# Altium schematic sheet STM32F405.SchDoc
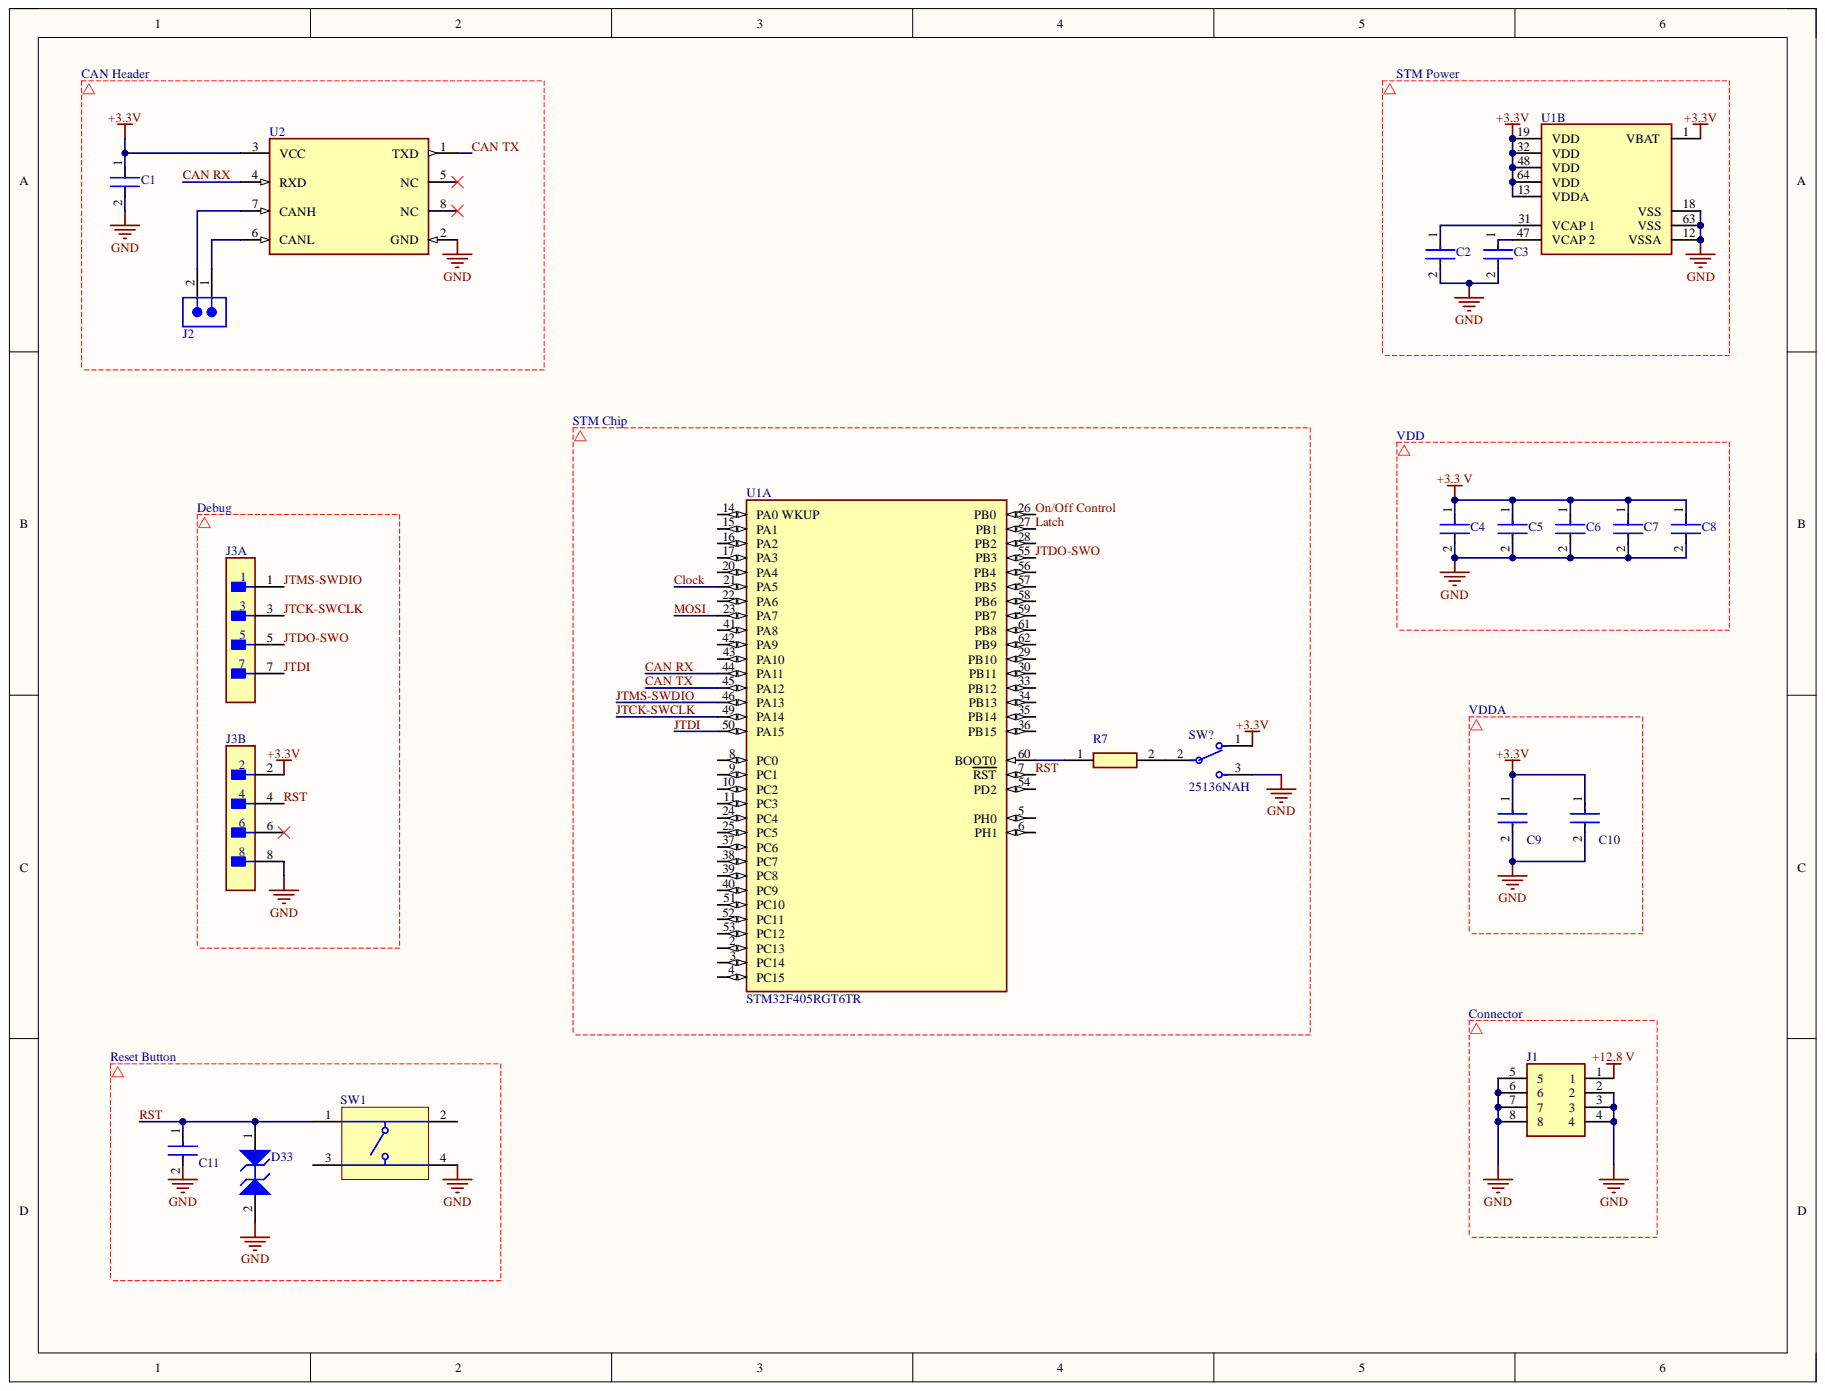
[diagram p0: STM32F405.SchDoc]

## Parts
C1  CGA3E2X7R1H104K080AA  [FP-CGA3E-0_3-0_1-IPC_A]  (value not drawn)
C2  C1005X5R1V225K050BC  [FP-C1005-050-0_15-IPC_A]  (value not drawn)
C3  C1005X5R1V225K050BC  [FP-C1005-050-0_15-IPC_A]  (value not drawn)
C4  CGA2B3X7R1E104KT  [FP-CGA2B-IPC_A]  (value not drawn)
C5  CGA2B3X7R1E104KT  [FP-CGA2B-IPC_A]  (value not drawn)
C6  CGA2B3X7R1E104KT  [FP-CGA2B-IPC_A]  (value not drawn)
C7  CGA2B3X7R1E104KT  [FP-CGA2B-IPC_A]  (value not drawn)
C8  C1005X5R1A475K050BC  [FP-C1005-050-0_15-MFG]  (value not drawn)
C9  CGA2B3X7R1E104K050BB  [FP-CGA2B-IPC_B]  (value not drawn)
C10  GRM155R61E105KA12D  [FP-GRM155-0_05-IPC_B]  (value not drawn)
C11  CL31B104KBP5PNF  [FP-CL31-IPC_C]  (value not drawn)
D33  ESD5B5.0ST1G  [ONSC-SOD-523-2-502-01_C_V]  (value not drawn)
J1  43045-0800  [43045-08(00,01,02,10)]  (value not drawn)
J2  679302124022  [679302124022]  (value not drawn)
J3  M20-9980446  [FP-M20-9980446-MFG]  (value not drawn)  (parts A,B)
R7  RP73PF1E10KBTD  [RESC1005X35N]  (value not drawn)
SW1  FSM4JSMATR  [TECO-FSM4JSMATR_V]  (value not drawn)
SW?  25136NAH  [FP-25136NAH-MFG]
U1  STM32F405RGT6TR  [FP-LQFP64-5W-IPC_C]  (parts A,B)
U2  TCAN332DCNR  [FP-DCN0008A-IPC_A]  (value not drawn)

## Nets
+3.3 V: C1.1 C4.1 C5.1 C6.1 C7.1 C8.1 C9.1 C10.1 J3.2 SW?.1 U1.1(VBAT) U1.13(VDDA) U1.19(VDD) U1.32(VDD) U1.48(VDD) U1.64(VDD) U2.3(VCC)
+12.8 V: J1.1
GND: C1.2 C2.2 C3.2 C4.2 C5.2 C6.2 C7.2 C8.2 C9.2 C10.2 C11.2 D33.2 J1.2 J1.3 J1.4 J1.5 J1.6 J1.7 J1.8 J3.8 SW1.4 SW?.3 U1.12(VSSA) U1.18(VSS) U1.63(VSS) U2.2(GND)
CAN RX: U1.44(PA11) U2.4(RXD)
CAN TX: U1.45(PA12) U2.1(TXD)
Clock: U1.21(PA5)
JTCK-SWCLK: J3.3 U1.49(PA14)
JTDI: J3.7 U1.50(PA15)
JTDO-SWO: J3.5 U1.55(PB3)
JTMS-SWDIO: J3.1 U1.46(PA13)
Latch: U1.27(PB1)
MOSI: U1.23(PA7)
On/Off Control: U1.26(PB0)
RST: C11.1 D33.1 J3.4 SW1.1 U1.7(RST)
NetC2_1: C2.1 U1.31(VCAP_1)
NetC3_1: C3.1 U1.47(VCAP_2)
NetJ2_1: J2.1 U2.6(CANL)
NetJ2_2: J2.2 U2.7(CANH)
NetR7_1: R7.1 U1.60(BOOT0)
NetR7_2: R7.2 SW?.2

Not connected: J3 6; SW1 2 3; U1 2(PC13) 3(PC14) 4(PC15) 5(PH0) 6(PH1) 8(PC0) 9(PC1) 10(PC2) 11(PC3) 14(PA0_WKUP) 15(PA1) 16(PA2) 17(PA3) 20(PA4) 22(PA6) 24(PC4) 25(PC5) 28(PB2) 29(PB10) 30(PB11) 33(PB12) 34(PB13) 35(PB14) 36(PB15) 37(PC6) 38(PC7) 39(PC8) 40(PC9) 41(PA8) 42(PA9) 43(PA10) 51(PC10) 52(PC11) 53(PC12) 54(PD2) 56(PB4) 57(PB5) 58(PB6) 59(PB7) 61(PB8) 62(PB9); U2 5(NC) 8(NC)

## Library data of the parts
C1 = CMP-2000-07546-2: Cap Ceramic 100nF 50V X7R 10% Pad SMD 0603 +125°C Automotive T/R; Capacitance: 100nF; Case Code (Imperial): 0603; Case Code (Metric): 1608; Case/Package: 0603; Component Kind: Standard; Composition: Ceramic; Depth: 0.8mm; Dielectric: X7R; Height: 0.8mm; Lead Free: Lead Free; Length: 1.6mm; Library Name: Altium Content Vault; Max Operating Temperature: 125°C; Min Operating Temperature: -55°C; Mount: Surface Mount; Package Quantity: 1; Packaging: Tape and Reel; Radiation Hardening: No; Ratings: AEC-Q200; REACH SVHC: No SVHC; Termination: SMD/SMT; Thickness: 0.035inch; Tolerance: 10%; Voltage: 50V; Voltage Rating: 50V; Voltage Rating (DC): 50V; Weight: 7.1E-05oz; Width: 0.8mm
C2 C3 = CMP-08246-007153-1: CAP CER 2.2UF 35V X5R 0402; Dielectric Material: Ceramic; Tolerance: 10%; Capacitance: 2.2uF; Case Code (Imperial): 0402; Case Code (Metric): 1005; Case/Package: 0402; Component Kind: Standard; Depth: 0.5mm; Dielectric: X5R; Height: 0.5mm; Lead Free: Lead Free; Length: 1mm; Library Name: Altium Content Vault; Max Operating Temperature: 85°C; Min Operating Temperature: -55°C; Mount: Surface Mount; Package Quantity: 1; Packaging: Tape and Reel; REACH SVHC: No SVHC; Resistor Type: Ceramic; RoHS Compliant: Yes; Series: C; Termination: SMD/SMT; Thickness: 0.022inch; Voltage Rating: 35V; Voltage Rating (DC): 35V; Weight: 2.3E-05oz; Width: 0.5mm
C4-C7 = CMP-08246-001442-1: Cap Ceramic 100nF 25V X7R 10% Pad SMD 0402 +125°C Automotive T/R; Case/Package: 0402; Component Kind: Standard; Library Name: Altium Content Vault
C8 = CMP-2000-05997-2: CAP CER 4.7UF 10V X5R 0402; Dielectric Material: Ceramic; Tolerance: 10%; Capacitance: 4.7uF; Case Code (Imperial): 0402; Case Code (Metric): 1005; Case/Package: 0402; Component Kind: Standard; Dielectric: X5R; Lead Free: Lead Free; Length: 0.039inch; Library Name: Altium Content Vault; Max Operating Temperature: 85°C; Min Operating Temperature: -55°C; Mount: Surface Mount; Package Quantity: 10000; Packaging: Cut Tape; REACH SVHC: No SVHC; RoHS Compliant: Yes; Series: C; Thickness: 0.022inch; Voltage Rating: 10V; Voltage Rating (DC): 10V; Width: 0.02inch
C9 = CMP-2000-06086-2: Cap Ceramic 100nF 25V X7R 10% Pad SMD 0402 +125°C Automotive T/R; Capacitance: 100nF; Case Code (Imperial): 0402; Case Code (Metric): 1005; Case/Package: 0402; Component Kind: Standard; Composition: Ceramic; Depth: 0.5mm; Dielectric: X7R; Height: 0.5mm; Lead Free: Lead Free; Length: 1mm; Library Name: Altium Content Vault; Max Operating Temperature: 125°C; Min Operating Temperature: -55°C; Mount: Surface Mount; Package Quantity: 1; Packaging: Tape and Reel; Ratings: AEC-Q200; REACH SVHC: No SVHC; Termination: SMD/SMT; Thickness: 0.022inch; Tolerance: 10%; Voltage: 25V; Voltage Rating: 25V; Voltage Rating (DC): 25V; Weight: 2.3E-05oz; Width: 0.02inch
C10 = CMP-2008-04581-2: Chip Multilayer Ceramic Capacitors for General Purpose, 0402, 1.0uF, X5R, 15%, 10%, 25V; Capacitance: 1uF; Case Code (Imperial): 0402; Case Code (Metric): 1005; Case/Package: 0402; Component Kind: Standard; Depth: 0.5mm; Dielectric: X5R; Height: 0.5mm; Lead Free: Lead Free; Length: 1mm; Library Name: Altium Content Vault; Material: Ceramic; Max Operating Temperature: 85°C; Min Operating Temperature: -55°C; Mount: Surface Mount; Package Quantity: 10000; Packaging: Tape and Reel; REACH SVHC: No SVHC; Termination: SMD/SMT; Thickness: 0.022inch; Tolerance: 10%; Voltage: 25V; Voltage Rating: 25V; Voltage Rating (DC): 25V; Weight: 0.000212oz; Width: 0.5mm
C11 = CMP-13271-001835-1: Cap Ceramic 100nF 50V X7R ±10% SMD 1206 +125°C Embossed T/R; Capacitance: 100nF; Case Code (Imperial): 1206; Case Code (Metric): 3216; Case/Package: 1206; Component Kind: Standard; Dielectric: X7R; Library Name: Altium Content Vault; Max Operating Temperature: 125°C; Min Operating Temperature: -55°C; Mount: Surface Mount; Packaging: Tape and Reel; Ratings: AEC-Q200; Tolerance: 10%; Voltage Rating: 50V
D33 = CMP-1063-00096-1: Transient Voltage Suppressor, Micro-Packaged Diode for ESD Protection, 2-Pin SOD-523, Pb-Free, Tape and Reel; Manufacturer: On Semiconductor; Direction: Bidirectional; IR Max (uA): 1; V(BR) Min (V): 5.8; VRWM Max (V): 5; Component Kind: Standard; ComponentLink1Description: Manufacturer URL; ComponentLink1URL: http://www.onsemi.com/; ComponentLink2Description: Datasheet; ComponentLink2URL: http://www.onsemi.cn/pub_link/Collateral/ESD5B5.0ST1G.PDF; ComponentLink3Description: Package Specification; ComponentLink3URL: http://www.onsemi.cn/pub_link/Collateral/ESD5B5.0ST1G.PDF; DatasheetVersion: Rev. 3; Library Name: Altium Content Vault; Mounting Technology: Surface Mount; Number of Lines: 1; PackageDescription: 2-Pin SOD, Body 1.2 x 0.8 mm; PackageReference: SOD-523-2-502-01_C; PackageVersion: Rev. C, 03/2007; Packing: Tape and Reel; PartNumber: ESD5B5.0ST1G; RoHS: Pb-Free
J1 = 43045-0800: Connector; Manufacturer_Name: Molex; Component Kind: Standard; Datasheet Link: https://www.molex.com/pdm_docs/sd/430450200_sd.pdf; Height: 8.77mm; Manufacturer_Part_Number: 43045-0800; Mouser Part Number: 538-43045-0800; Mouser Price/Stock: https://www.mouser.co.uk/ProductDetail/Molex/43045-0800?qs=MGlPTwjtJ4Z70Z3DbkGxKw%3D%3D
J2 = CMP-1502-00721-2: NexarPartId: 18818281; Connector Type: Male Pin; Contact Resistance: 20mΩ; Current Rating: 1A; Gender: Male; Insulation: Insulated; Insulation Resistance: 100MΩ; Number of Contacts: 2; Operating Supply Voltage: 50V; Pitch: 1.5mm; Color: Beige, White; Component Kind: Standard; Contact Gender: Male; Contact Material: Copper; Contact Plating: Tin; Flammability Rating: UL94 V-0; Height: 5mm; Housing Material: Nylon; Lead Pitch: 1.5mm; Length: 6mm; Library Name: Altium Content Vault; Max Operating Temperature: 85°C; Min Operating Temperature: -25°C; Mount: Surface Mount; Number of Pins: 2; Number of Positions: 2; Number of Rows: 1; Orientation: Vertical; Packaging: Tape and Reel; REACH SVHC: Unknown; RoHS: Compliant; Termination: SMD/SMT; Voltage Rating: 50V; Width: 6mm
J3 = CMP-15831-000088-1: CONN HEADER VERT 8POS 2.54MM; Connector Type: Male Pin; Contact Resistance: 30mR; Current Rating: 3A; Gender: Male; Insulation Resistance: 10GR; Mated Stacking Heights: 16.54mm; Number of Contacts: 8; Row Spacing: 0.1inch; Color: Black; Component Kind: Standard; Contact Finish - Mating: TIN; Contact Material: Brass; Contact Mating Length: 0.24inch; Contact Plating: Tin; Contact Shape: Square; Contact Type: Male Pin; Depth: 5.08mm; Fastening Type: Pull; Flammability Rating: UL94 V-0; Housing Color: Black; Housing Material: Nylon; Insulation Color: Black; Insulation Material: Nylon; Length: 10.16mm; Library Name: Altium Content Vault; Max Current Rating: 3A; Max Operating Temperature: 105°C; Min Operating Temperature: -40°C; Mount: Through Hole; Number of Positions: 8; Number of Rows: 2; Orientation: Straight; Package Quantity: 1000; Packaging: Bulk; Pitch: 2.54mm; Radiation Hardening: No; REACH SVHC: No SVHC; RoHS Compliant: Yes; Series: M20; Termination: Solder
R7 = RP73PF1E10KBTD: Resistor; Manufacturer_Name: TE Connectivity; Arrow Part Number: RP73PF1E10KBTD; Arrow Price/Stock: https://www.arrow.com/en/products/rp73pf1e10kbtd/te-connectivity?region=nac; Component Kind: Standard; Datasheet Link: https://www.te.com/commerce/DocumentDelivery/DDEController?Action=showdoc&DocId=Customer+Drawing%7F2176306%7FA%7Fpdf%7FEnglish%7FENG_CD_2176306_A.pdf%7F9-2176306-1; Height: 0.35mm; Manufacturer_Part_Number: RP73PF1E10KBTD; Mouser Part Number: 279-RP73PF1E10KBTD; Mouser Price/Stock: https://www.mouser.co.uk/ProductDetail/TE-Connectivity-Holsworthy/RP73PF1E10KBTD?qs=r5DSvlrkXmKxfYOoKpKMYA%3D%3D
SW1 = CMP-2000-07486-1: Micro Miniature Push Button Switch FSM JSM, 50 mA, -35 to 85 degC, 4-Pin SMD, RoHS, Tape and Reel; Manufacturer: TE Connectivity; Actuator Length: 0.055inch; Actuator Material: Polyamide; Capacitance: 0f; Case\/Package: SMD/SMT; Contact Current Rating: 50mA; Contact Resistance: 100mOhm; Electrical Life: 100000Cycles; Illumination Type: Illuminated; Max Voltage Rating (DC): 12V; Operating Force: 160gf; Switch Travel: 0.25mm; Throw Configuration: SPST; Voltage Rating (DC): 24V; Component Kind: Standard; Contact Material: CopperAlloy; Contact Plating: Silver; Current Rating: 50mA; Datasheet URL: http://www.te.com/commerce/DocumentDelivery/DDEController?Action=showdoc&DocId=Customer+Drawing%7F2-1437565-7%7FV%7Fpdf%7FEnglish%7FENG_CD_2-1437565-7_V.pdf%7F4-1437565-2; Datasheet Version: Rev. V, 02/2015; Library Name: Altium Content Vault; Manufacturer URL: http://www.te.com/; Max Operating Temperature: 85degC; Mechanical Life: 100000Cycles; Min Operating Temperature: -35degC; Mount: SurfaceMount; Mounting Technology: SurfaceMount; Orientation: Straight; Package Description: 4-Pin Surface Mount Device, Body 6 x 6 mm, Pitch 4.5 mm; Package Quantity: 1200; Package Reference: FSM4JSMATR; Packaging: TapeandReel; REACH SVHC: Unknown; RoHSCompliant: true; Termination: GullWing
SW? = CMP-47022-000001-1: SWITCH SLIDE SPDT 4A 125V; Actuator Length: 2.8mm; Actuator Material: Nylon; Actuator Type: Standard; Contact Resistance: 10mR; Current Rating: 1A; Electrical Life: 10000Cycles; Illumination Type: Non-Illuminated; Insulation Resistance: 1GR; Max Voltage Rating (AC): 250V; Max Voltage Rating (DC): 30V; Mechanical Life: 20000Cycles; Switch Function: On-On; Switch Travel: 4mm; Component Kind: Standard; Contact Current Rating: 4A; Contact Material: Silver; Contact Plating: Silver; Depth: 7.5mm; Length: 14.1mm; Library Name: Altium Content Vault; Max Current Rating: 1A; Max Operating Temperature: 85°C; Min Operating Temperature: -40°C; Mount: Through Hole; Orientation: Vertical; Package Quantity: 100; Packaging: Bulk; REACH SVHC: No SVHC; Termination: PC Pins; Throw Configuration: SPDT; Voltage Rating (AC): 125V; Voltage Rating (DC): 30V
U1 = CMP-0243-00156-2: IC MCU 32BIT 1MB FLASH 64LQFP; Core Architecture: ARM; Data Bus Width: 32b; Frequency: 168MHz; Interface: I2C; Max Power Dissipation: 435mW; Max Supply Voltage: 3.6V; Memory Size: 1024KB; Min Supply Voltage: 1.8V; Number of ADC Channels: 16; Number of I2C Channels: 3; Number of I/Os: 51; Number of Timers/Counters: 14; Number of UART Channels: 2; Oscillator Type: Internal; Peripherals: Brown-out Detect/Reset; RAM Size: 196KB; Watchdog Timer: Yes; Case/Package: LQFP; Component Kind: Standard; Contact Plating: Tin; Height: 1.45mm; Lead Free: Lead Free; Length: 10mm; Library Name: Altium Content Vault; Max Frequency: 168MHz; Max Operating Temperature: 85°C; Memory Type: FLASH; Min Operating Temperature: -40°C; Mount: Surface Mount; Number of Pins: 64; Number of Programmable I/O: 51; Number of SPI Channels: 3; Number of USB Channels: 2; Package Quantity: 1000; Packaging: Tape and Reel; Radiation Hardening: No; Width: 10mm
U2 = CMP-04872-000203-1: IC TRANSCEIVER 1/1 SOT23-8; Receiver Hysteresis: 120mV; Case/Package: SOT-23-8; Component Kind: Standard; Lead Free: Lead Free; Library Name: Altium Content Vault; Max Operating Temperature: 125°C; Min Operating Temperature: -40°C; Mount: Surface Mount; Packaging: Tape and Reel; RoHS Compliant: Yes
